# Altium schematic sheet MQ.SchDoc(MQ)
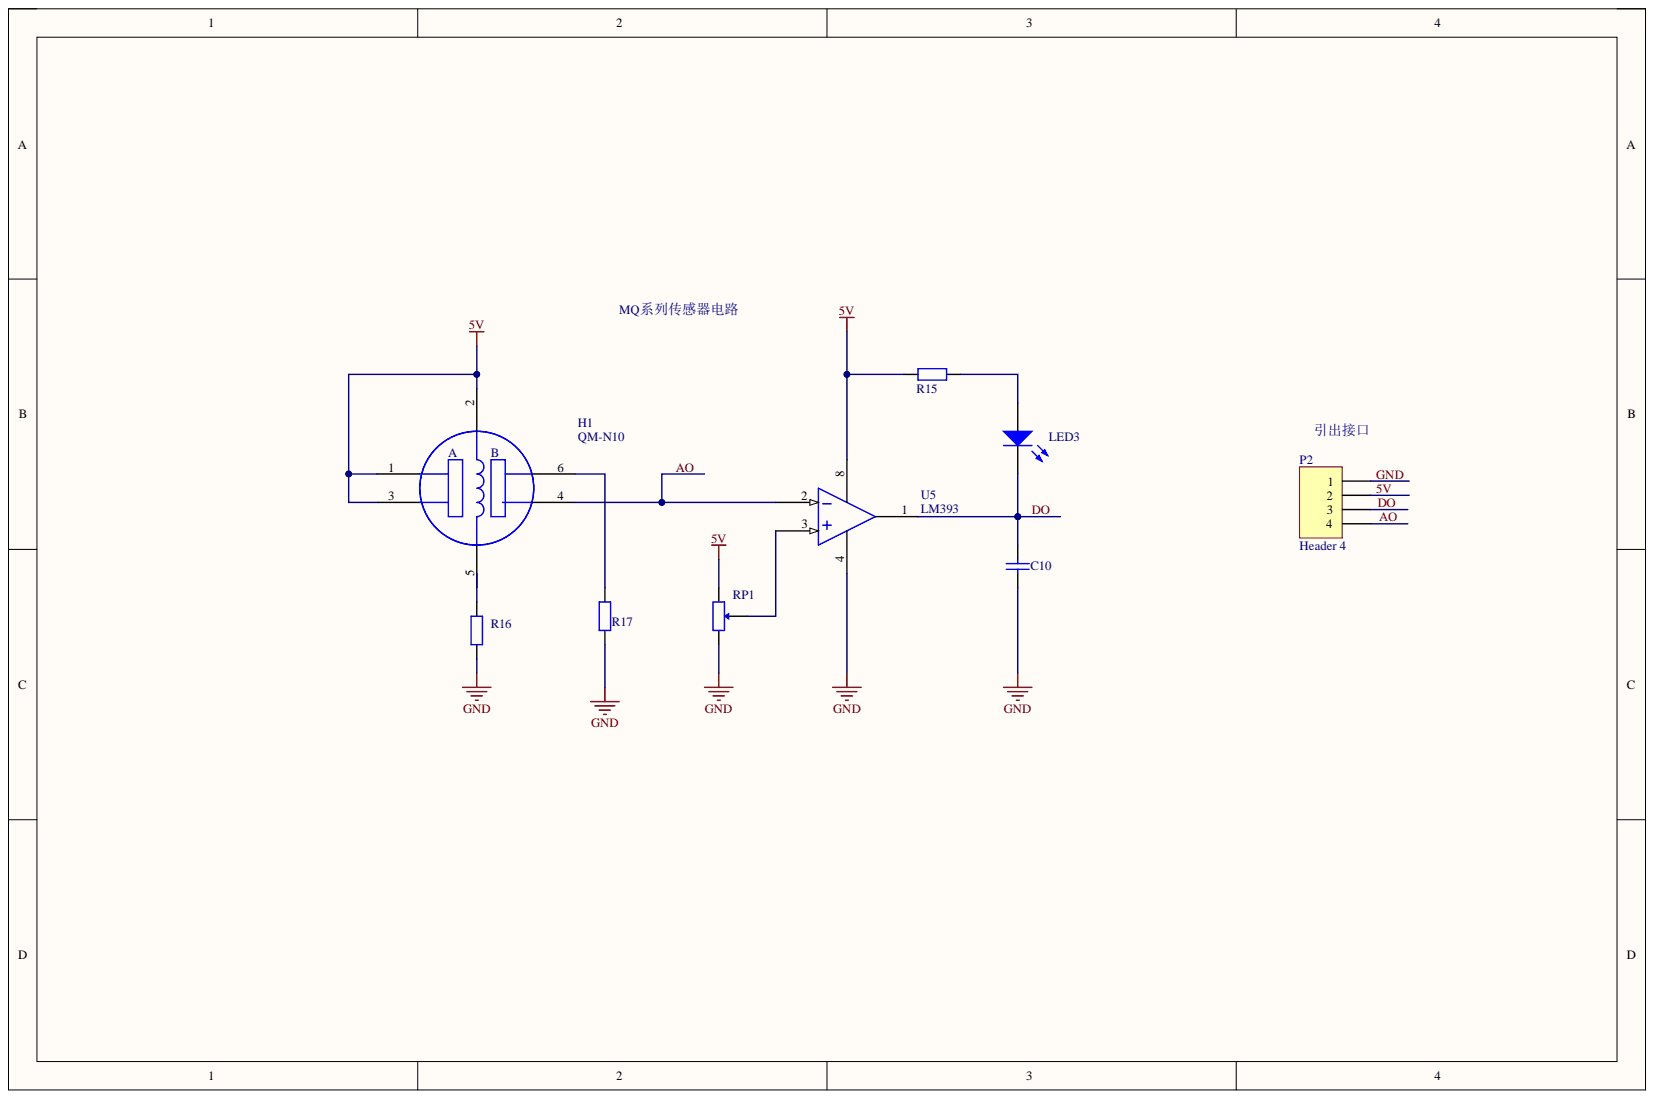
[diagram p0: MQ.SchDoc]

## Parts
C10  104  [C0805_L]  (value not drawn)
H1  QM-N10
LED3  红色  [LED0805_G]  (value not drawn)
P2  Header 4  [HDR1X4]
R15  1K  [R0805_L]  (value not drawn)
R16  1K  [R0805_L]  (value not drawn)
R17  1K  [R0805_L]  (value not drawn)
RP1  10K  [3303W]  (value not drawn)
U5  LM393

## Nets
5V: P2.2 R15.2 RP1.1
AO: P2.4 U5.2
DO: C10.2 LED3.2 P2.3
GND: C10.1 P2.1 R16.1 R17.1 RP1.3
NetLED3_1: LED3.1 R15.1
NetRP1_2: RP1.2 U5.3

Not connected: H1 1 2 3 4 5 6; R16 2; R17 2; U5 1 4 8

## Library data of the parts
C10 = C: 贴片电容/MLCC电容; BOM名称: 无极性贴片电容; 联系下单店铺卖家 免费帮你查找封装: 联系下单店铺卖家 免费技术支持; Component Kind: Standard; Library Name: 0.1 - 电阻-电容-电感-保险丝-晶振-晶体-磁珠-电位器-法拉电容-馈通电容.SchLib
LED3 = LED: 贴片LED; 封装库来自淘宝卖家：黄晶宝石: 其他店铺购入均为盗版封装库，无更新权益，退款现金奖励200~1000元; BOM名称: 贴片LED; Component Kind: Standard; Library Name: 0.3 - LED发光二极管-IR二极管_接收管-光耦.SchLib
P2 = Header 4: Header, 4-Pin; Component Kind: Standard; LatestRevisionDate: 17-Jul-2002; LatestRevisionNote: Re-released for DXP Platform.; Library Name: Miscellaneous Connectors.IntLib; Signal Integrity: Connector; Publisher: Altium Limited
R15-R17 = R: 贴片电阻; 当前库版本V2022.10.7 @黄晶宝石: 最新版本发布https://shop463601444.taobao.com; BOM名称: 贴片电阻; Component Kind: Standard; Library Name: 0.1 - 电阻-电容-电感-保险丝-晶振-晶体-磁珠-电位器-法拉电容-馈通电容.SchLib
RP1 = RP-SMD: 贴片电位器; 联系下单店铺卖家 免费帮你查找封装: 联系下单店铺卖家 免费技术支持; BOM名称: 贴片电位器; Component Kind: Standard; Library Name: 0.1 - 电阻-电容-电感-保险丝-晶振-晶体-磁珠-电位器-法拉电容-馈通电容.SchLib
H1 = QM-N10: Component Kind: Standard; Library Name: MQ-2.SchLib
U5 = LM393: Component Kind: Standard; Library Name: MQ-2.SchLib
